# Altium schematic sheet main.SchDoc(main)
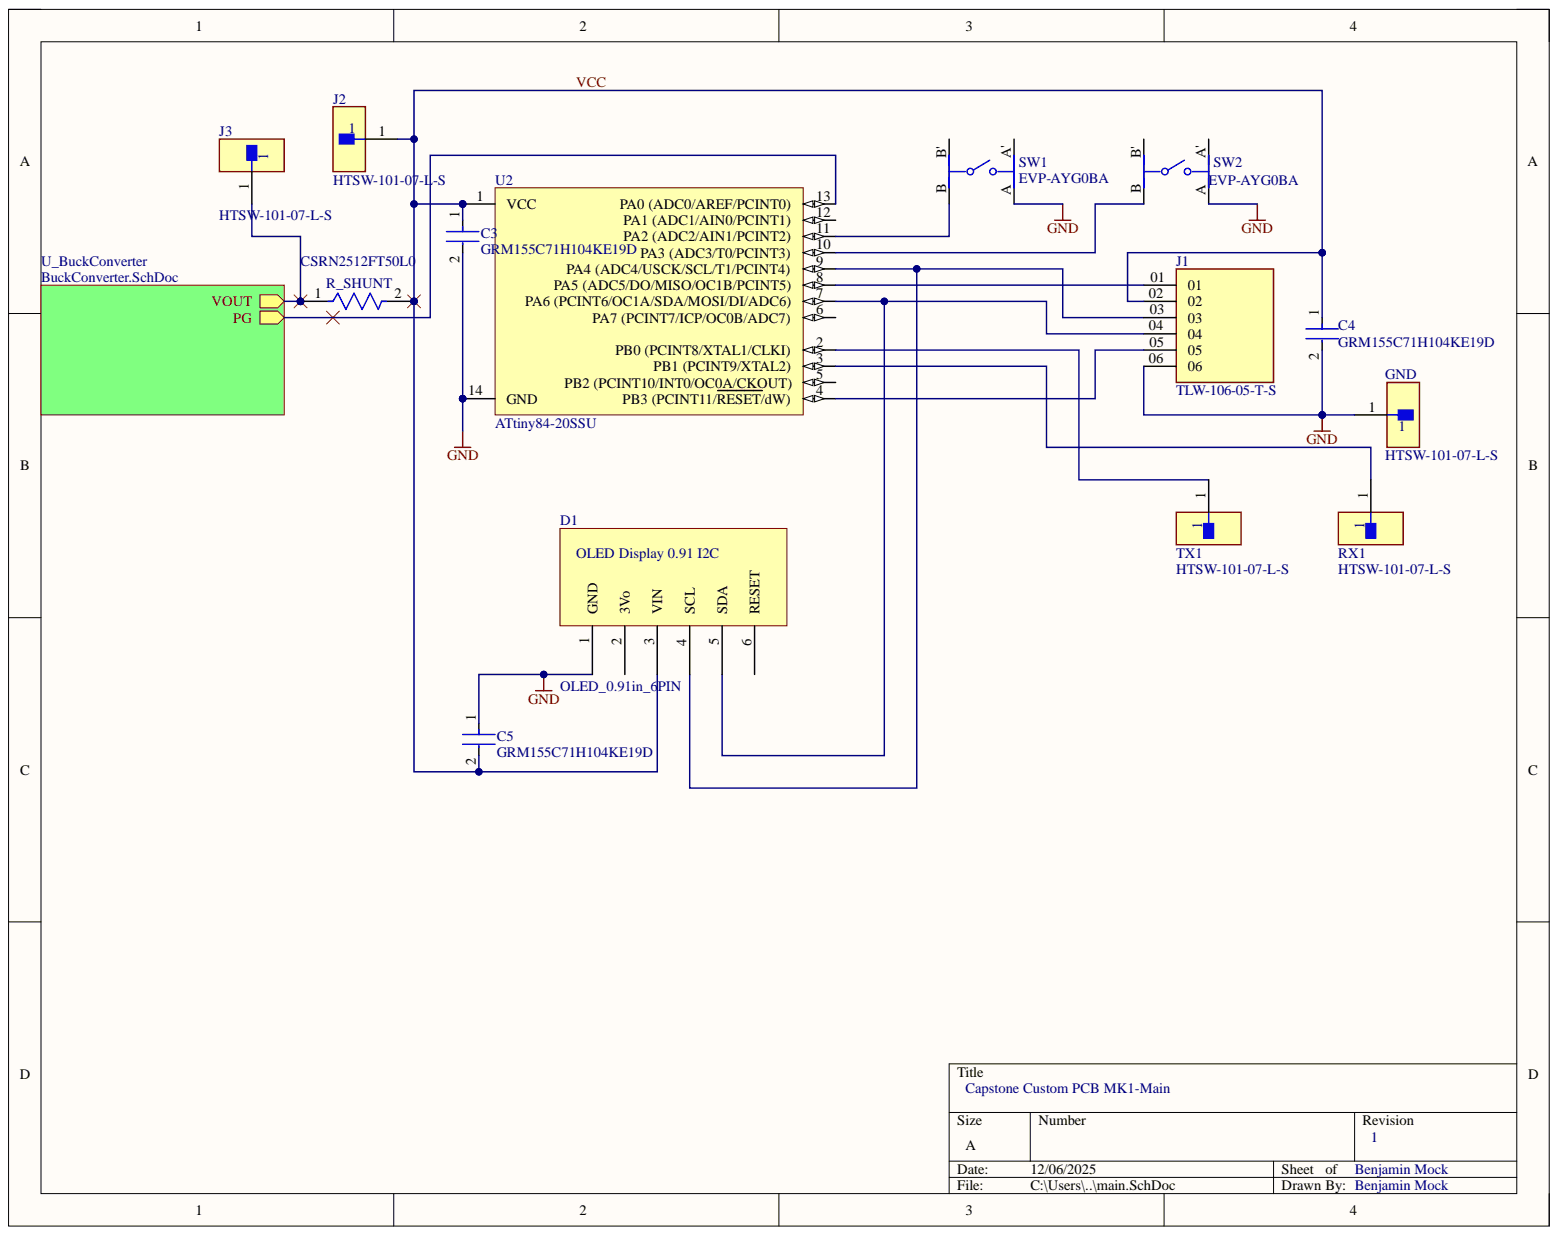
[diagram p0: main.SchDoc]

## Parts
C3  GRM155C71H104KE19D  [FP-GRM155-0_05-MFG]
C4  GRM155C71H104KE19D  [FP-GRM155-0_05-MFG]
C5  GRM155C71H104KE19D  [FP-GRM155-0_05-MFG]
D1  OLED_0.91in_6PIN  [PCBComponent_1]
GND  HTSW-101-07-L-S  [FP-HTSW-101-07-L-S-MFG]
J1  TLW-106-05-T-S  [SAMTEC_TLW-106-05-T-S]
J2  HTSW-101-07-L-S  [FP-HTSW-101-07-L-S-MFG]
J3  HTSW-101-07-L-S  [FP-HTSW-101-07-L-S-MFG]
R_SHUNT  CSRN2512FT50L0  [FP-CSRN2512-IPC_B]
RX1  HTSW-101-07-L-S  [FP-HTSW-101-07-L-S-MFG]
SW1  EVP-AYG0BA  [FP-EVP-AYG0BA-MFG]
SW2  EVP-AYG0BA  [FP-EVP-AYG0BA-MFG]
TX1  HTSW-101-07-L-S  [FP-HTSW-101-07-L-S-MFG]
U2  ATtiny84-20SSU  [14S1_N]

## Nets
GND: C3.2 C4.2 C5.1 D1.1(GND) GND.1 J1.06 SW1.A SW2.A U2.14(GND)
VCC: C3.1 C4.1 C5.2 D1.3(VIN) J1.02 J2.1 R_SHUNT.2 U2.1(VCC)
NetD1_2: D1.2(3Vo)
NetD1_4: D1.4(SCL) J1.03 U2.9(PA4_(ADC4/USCK/SCL/T1/PCINT4))
NetD1_5: D1.5(SDA) J1.04 U2.7(PA6_(PCINT6/OC1A/SDA/MOSI/DI/ADC6))
NetD1_6: D1.6(RESET)
NetJ1_01: J1.01 U2.8(PA5_(ADC5/DO/MISO/OC1B/PCINT5))
NetJ1_05: J1.05 U2.4
NetJ3_1: J3.1 R_SHUNT.1
NetRX1_1: RX1.1 U2.3(PB1_(PCINT9/XTAL2))
NetSW1_A': SW1.A'
NetSW1_B: SW1.B U2.11(PA2_(ADC2/AIN1/PCINT2))
NetSW1_B': SW1.B'
NetSW2_A': SW2.A'
NetSW2_B: SW2.B U2.10(GRM155C71H104KE19D_PA3_(ADC3/T0/PCINT3))
NetSW2_B': SW2.B'
NetTX1_1: TX1.1 U2.2(PB0_(PCINT8/XTAL1/CLKI))
NetU2_5: U2.5(PB2_(PCINT10/INT0/OC0A/CKOUT))
NetU2_6: U2.6(PA7_(PCINT7/ICP/OC0B/ADC7))
NetU2_12: U2.12(PA1_(ADC1/AIN0/PCINT1))
NetU2_13: U2.13

## Library data of the parts
C3-C5 = CMP-06035-019038-1: Chip Multilayer Ceramic Capacitors for General Purpose, 0402, 0.10uF, X7S, 22%, 10%, 50V; Capacitance: 100nF; Component Kind: Standard; Dielectric: X7S; Length: 1mm; Library Name: Altium Content Vault; Thickness: 500um; Tolerance: 10%; Voltage Rating (DC): 50V; Width: 500um
GND J2 J3 RX1 TX1 = CMP-02766-001464-1: CONN HEADER VERT 1POS; Gender: Male; Max Current Rating: 7.6A; Max Voltage Rating (AC): 550V; Number of Contacts: 1; Component Kind: Standard; Contact Material: Bronze; Contact Plating: Gold; Depth: 2.48mm; Housing Color: Natural; Housing Material: Polymer; Length: 2.54mm; Library Name: Altium Content Vault; Max Operating Temperature: 125°C; Min Operating Temperature: -55°C; Number of Rows: 1; Orientation: Straight; Packaging: Bulk; Radiation Hardening: No; REACH SVHC: No SVHC; RoHS Compliant: Yes; Termination: Solder
R_SHUNT = CMP-26527-002421-1: Res Thick Film 2512 50mΩ 1% 2W ±200ppm/°C Molded SMD Plastic T/R; Resistance: 50mR; Case/Package: 2512; Component Kind: Standard; Height: 0.028inch; Length: 0.25inch; Library Name: Altium Content Vault; Max Operating Temperature: 155°C; Max Power Dissipation: 2W; Min Operating Temperature: -55°C; Mount: Surface Mount; Number of Terminations: 2; Package Quantity: 4000; Packaging: Tape and Reel; Resistor Type: Thick Film; Series: CSRN; Temperature Coefficient: 200ppm/°C; Tolerance: 1%; Width: 0.124inch
SW1 SW2 = CMP-05618-000108-1: SWITCH TACTILE SPST-NO 0.02A 15V; Actuator Type: Standard; Illumination Type: Non-Illuminated; Mechanical Life: 500000Cycles; Operating Force: 240gf; Switch Function: Off-Mom; Switch Travel: 0.15mm; Throw Configuration: SPST; Component Kind: Standard; Library Name: Altium Content Vault; Max Operating Temperature: 85°C; Min Operating Temperature: -40°C; Mount: Surface Mount; Packaging: Tape and Reel
U2 = CMP-0093-00133-2: 8-bit AVR Microcontroller, 20MHz, 2.7-5.5V, 8KB Flash, 512 Bytes EEPROM, 512 Bytes SRAM, 14-pin SOIC, Industrial Grade (-40°C to 85°C), Pb-Free; Code_IPC: SOIC127P600-14; Code_JEDEC: MS-012-AB; Component Kind: Standard; ComponentLink1Description: Manufacturer URL; ComponentLink1URL: http://www.atmel.com/; ComponentLink2Description: ATtiny24/44/84 Datasheet; ComponentLink2URL: http://www.atmel.com/dyn/resources/prod_documents/doc8006.pdf; ComponentLink3Description: ATtiny24/44/84 Summary; ComponentLink3URL: http://www.atmel.com/dyn/resources/prod_documents/8006S.pdf; DatasheetVersion: revK, Oct-2010; Library Name: Altium Content Vault; PackageDescription: 14-Lead, 0.150â€ Wide Body, Plastic Gull Wing Small Outline Package (SOIC); PackageReference: 14S1; PackageVersion: revA, Feb-2002; RevisionNote: DatasheetVersion updated. URLs added.
D1 = OLED_0.91in_6PIN: Component Kind: Standard; Library Name: OLED_Display.SchLib
J1 = TLW-106-05-T-S: Availability: Unavailable; Component Kind: Standard; Library Name: TLW-106-05-T-S.IntLib; MP: TLW-106-05-T-S; Package: None; Price: None
